# Altium schematic sheet Khoi_trung_Tam.SchDoc
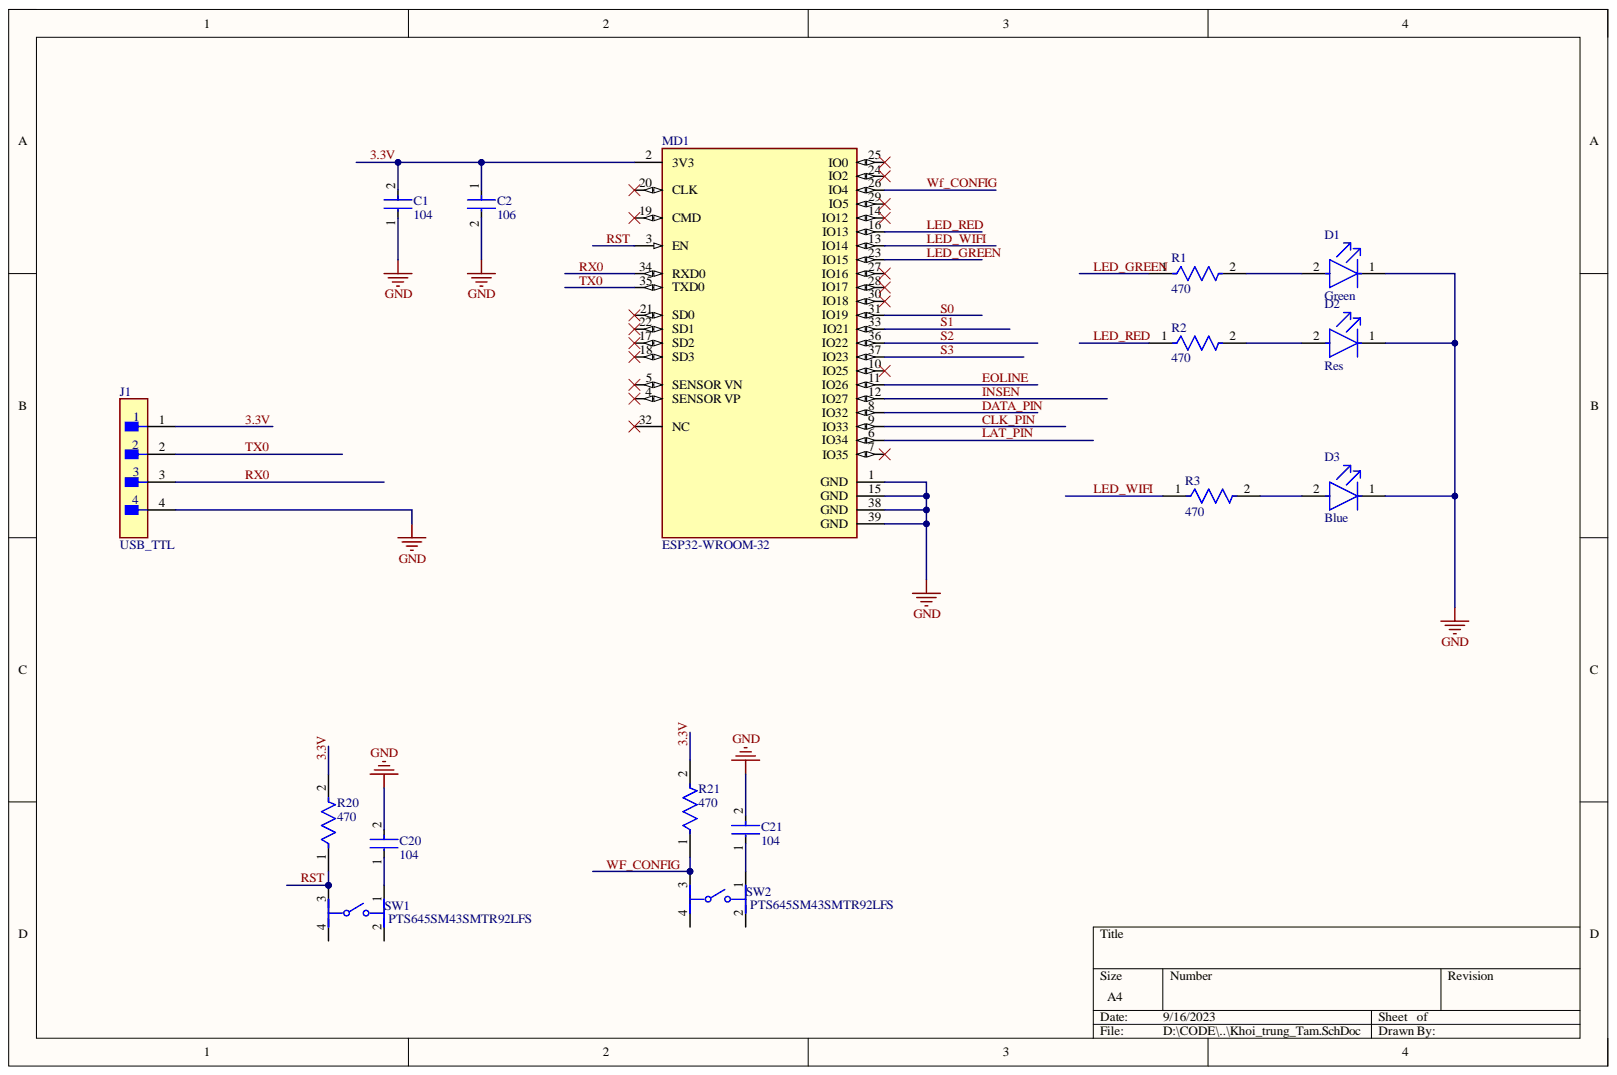
[diagram p0: Khoi_trung_Tam.SchDoc]

## Parts
C1  104  [FP-GRM15-MFG]
C2  106  [FP-GRM188-0_1-e0_2_0_55-IPC_A]
C20  104  [FP-GRM15-MFG]
C21  104  [FP-GRM15-MFG]
D1  Green  [FP-LTST-C190GKT-MFG]
D2  Res  Color: Green  [FP-LTST-C190GKT-MFG]
D3  Blue  [FP-LTST-C190GKT-MFG]
J1  USB_TTL  [FP-DF13-4P-1_25DSA-0]
MD1  ESP32-WROOM-32  [FP-ESP32-WROOM-32-MFG]
R1  470  [FP-ERJ2RK-MFG]
R2  470  [FP-ERJ2RK-MFG]
R3  470  [FP-ERJ2RK-MFG]
R20  470  [FP-ERJ2RK-MFG]
R21  470  [FP-ERJ2RK-MFG]
SW1  PTS645SM43SMTR92LFS  [FP-PTS645SM43SMTR92LFS-MFG]
SW2  PTS645SM43SMTR92LFS  [FP-PTS645SM43SMTR92LFS-MFG]

## Nets
GND: C1.1 C2.2 C20.2 C21.2 D1.1 D2.1 D3.1 J1.4 MD1.1(GND) MD1.15(GND) MD1.38(GND) MD1.39(GND)
3.3V: C1.2 C2.1 J1.1 MD1.2(3V3) R20.2 R21.2
CLK_PIN: MD1.9(IO33)
DATA_PIN: MD1.8(IO32)
EOLINE: MD1.11(IO26)
INSEN: MD1.12(IO27)
LAT_PIN: MD1.6(IO34)
LED_GREEN: MD1.23(IO15) R1.1
LED_RED: MD1.16(IO13) R2.1
LED_WIFI: MD1.13(IO14) R3.1
RST: MD1.3(EN) R20.1 SW1.3
RX0: J1.3 MD1.34(RXD0)
S0: MD1.31(IO19)
S1: MD1.33(IO21)
S2: MD1.36(IO22)
S3: MD1.37(IO23)
TX0: J1.2 MD1.35(TXD0)
Wf_CONFIG: MD1.26(IO4) R21.1 SW2.3
NetC20_1: C20.1 SW1.1
NetC21_1: C21.1 SW2.1
NetD1_2: D1.2 R1.2
NetD2_2: D2.2 R2.2
NetD3_2: D3.2 R3.2

Not connected: MD1 4(SENSOR_VP) 5(SENSOR_VN) 7(IO35) 10(IO25) 14(IO12) 17(SD2) 18(SD3) 19(CMD) 20(CLK) 21(SD0) 22(SD1) 24(IO2) 25(IO0) 27(IO16) 28(IO17) 29(IO5) 30(IO18) 32(NC); SW1 2 4; SW2 2 4

## Library data of the parts
C1 C20 C21 = CMP-2100-03641-2: CAP CER 0.1UF 16V X7R 0402; Manufacturer: Murata; Manufacturer Part Number: GRM155R71C104KA88D; Capacitance: 0.10μF; Material: Ceramic; Standoff Height: 0mm; Tolerance: 10%; Value: 0.10μF; Voltage Rating: 16Vdc; Category: Cap; Component Kind: Standard; ComponentLink2Description: Datasheet; ComponentLink2URL: https://search.murata.co.jp/Ceramy/image/img/A01X/G101/ENG/GRM155R71H471KA01-01A.pdf; Device Class L1: Passive Components; Device Class L2: Capacitors; Device Class L3: Ceramic Capacitors; Digikey Description: CAP CER 0.1UF 16V X7R 0402; Height: 0.55mm; Ipc Land Pattern Name: CAPC10050X219; Lead Free: yes; Library Name: Altium Content Vault; Mouser Description: 0402 0.1 uF 16V ±10 % Tolerance X7R SMT Multilayer Ceramic Capacitor; Octopart: https://octopart.com/grm155r71c104ka88d-murata-196880; Package: GRM15; RoHS: TRUE; Temperature: -55°C to +125°C; Temperature Characteristic: X7R; Temperature Range High: +125°C; Temperature Range Low: -55°C
C2 = CMP-2006-02792-2: Chip Multilayer Ceramic Capacitors for General Purpose, 0603, 10uF, X5R, 15%, 20%, 6.3V; Capacitance: 10uF; Case Code (Imperial): 0603; Case Code (Metric): 1608; Case/Package: 0603; Component Kind: Standard; Depth: 0.8mm; Dielectric: X5R; Height: 0.8mm; Lead Free: Lead Free; Length: 1.6mm; Library Name: Altium Content Vault; Material: Ceramic; Max Operating Temperature: 85°C; Min Operating Temperature: -55°C; Mount: Surface Mount; Package Quantity: 4000; Packaging: Tape and Reel; Radiation Hardening: No; REACH SVHC: No SVHC; Termination: SMD/SMT; Thickness: 0.035inch; Tolerance: 20%; Voltage: 6.3V; Voltage Rating: 6.3V; Voltage Rating (DC): 6.3V; Width: 0.031inch
D1-D3 = CMP-22017-000005-2: LED GREEN CLEAR CHIP SMD; Dominant Wavelength: 569nm; Forward Current: 30mA; Forward Voltage: 2.1V; Lens Color: Colorless; Luminous Intensity: 6mcd; Number of Elements: 1; Power Dissipation: 100mW; Reverse Voltage: 5V; Test Current: 10mA; Viewing Angle: 130°; Voltage: 2V; Wavelength: 565nm; Case Code (Imperial): 0603; Case Code (Metric): 1608; Case/Package: 0603; Component Kind: Standard; Depth: 0.8mm; Height: 0.8mm; Illumination Color: Green; Lead Free: Lead Free; Length: 1.6mm; Lens Style: Clear; Lens Transparency: Clear; Library Name: Altium Content Vault; Max Operating Temperature: 85°C; Min Operating Temperature: -55°C; Mount: Surface Mount; Number of LEDs: 1; Number of Pins: 2; Package Quantity: 1; Packaging: Tape and Reel; Power Rating: 100mW; Radiation Hardening: No; Width: 0.8mm
  D1 D3: Color: Green
J1 = CMP-11610-000002-1: CONN HEADER VERT 4POS 1.25MM; Manufacturer: Hirose Electric; Manufacturer Part Number: DF13-4P-1.25DSA; Conn Gender: Male; Conn Orientation: Straight; Current Rating: 1A; Number Of Contacts: 4; Voltage Rating: 150V; Automotive: No; Category: Conn; Component Kind: Standard; ComponentLink2Description: Datasheet; ComponentLink2URL: https://www.hirose.com/product/document?clcode=CL0536-0103-4-00&productname=DF13-4P-1.25DSA&series=DF13&documenttype=Catalog&lang=en&documentid=D31687_en; Contact Material: Tin; Device Class L1: Connectors; Device Class L2: Headers and Wire Housings; Device Class L3: unset; Digikey Description: CONN HEADER VERT 4POS 1.25MM; Footprint Url: https://www.hirose.com/product/document?clcode=CL0536-0103-4-00&productname=DF13-4P-1.25DSA&series=DF13&documenttype=2DDrawing&lang=en&documentid=0000986121; Height: 4.6mm; Lead Free: Yes; Library Name: Altium Content Vault; Mouser Description: Headers & Wire Housings 1.25MM V PCB HEADER 4P THRU-HOLE TIN; Number Of Rows: 1; Octopart: https://octopart.com/df13-4p-1.25dsa-hirose-261607; Package: DF13-4P-1.25DSA; Pitch: 1.25mm; RoHS: TRUE; Temperature: -35°C to +85°C; Temperature Range High: +85°C; Temperature Range Low: -35°C
MD1 = CMP-194065-000008-1: RX TXRX MOD WIFI SURFACE MOUNT; Data Rate: 150Mbps; Frequency: 2.5GHz; Operating Supply Voltage: 3.6V; Sensitivity: -98dBm; Case/Package: SMD/SMT; Component Kind: Standard; Library Name: Altium Content Vault; Max Operating Temperature: 85°C; Min Operating Temperature: -40°C; Mount: Surface Mount; Package Quantity: 550; Packaging: Cut Tape; Weight: 0.088185oz
R1-R3 R20 R21 = CMP-2002-00608-4: RES SMD 100K OHM 1% 1/10W 0402; Max Power Dissipation: 100mW; Resistance: 100kR; Temperature Coefficient: 100ppm/°C; Voltage Rating: 50V; Case Code (Imperial): 0402; Case Code (Metric): 1005; Case/Package: 0402; Component Kind: Standard; Composition: Thick Film; Contact Plating: Tin; Depth: 0.5mm; Features: Automotive AEC-Q200; Height: 0.35mm; Lead Free: Lead Free; Length: 1mm; Library Name: Altium Content Vault; Max Operating Temperature: 155°C; Military Standard: Not; Min Operating Temperature: -55°C; Mount: Surface Mount; Number of Pins: 2; Number of Terminations: 2; Package Quantity: 1; Packaging: Tape and Reel; Power Rating: 100mW; Radiation Hardening: No; REACH SVHC: No SVHC; Termination: SMD/SMT; Tolerance: 1%; Voltage Rating (DC): 50V; Width: 0.5mm
SW1 SW2 = CMP-2000-05160-2: SWITCH TACTILE SPST-NO 0.05A 12V; Actuator Length: 0.85mm; Actuator Material: Nylon; Actuator Type: Standard; Contact Resistance: 100mR; Current Rating: 50mA; Illumination Type: Non-Illuminated; Mechanical Life: 100000Cycles; Operating Force: 160gf; Switch Function: Off-Mom; Throw Configuration: SPST; Case/Package: SMD/SMT; Color: Black; Component Kind: Standard; Contact Material: Silver; Contact Plating: Silver; Depth: 9mm; Lead Free: Lead Free; Length: 6mm; Library Name: Altium Content Vault; Max Current Rating: 50mA; Max Operating Temperature: 60°C; Max Voltage Rating (DC): 12V; Min Operating Temperature: -20°C; Mount: Surface Mount; Orientation: Straight; Package Quantity: 1; Packaging: Tape and Reel; REACH SVHC: No SVHC; Termination: Gull Wing; Voltage Rating (DC): 12V
